# Altium schematic sheet NC_Connectors.SchDoc(NC_Connectors)
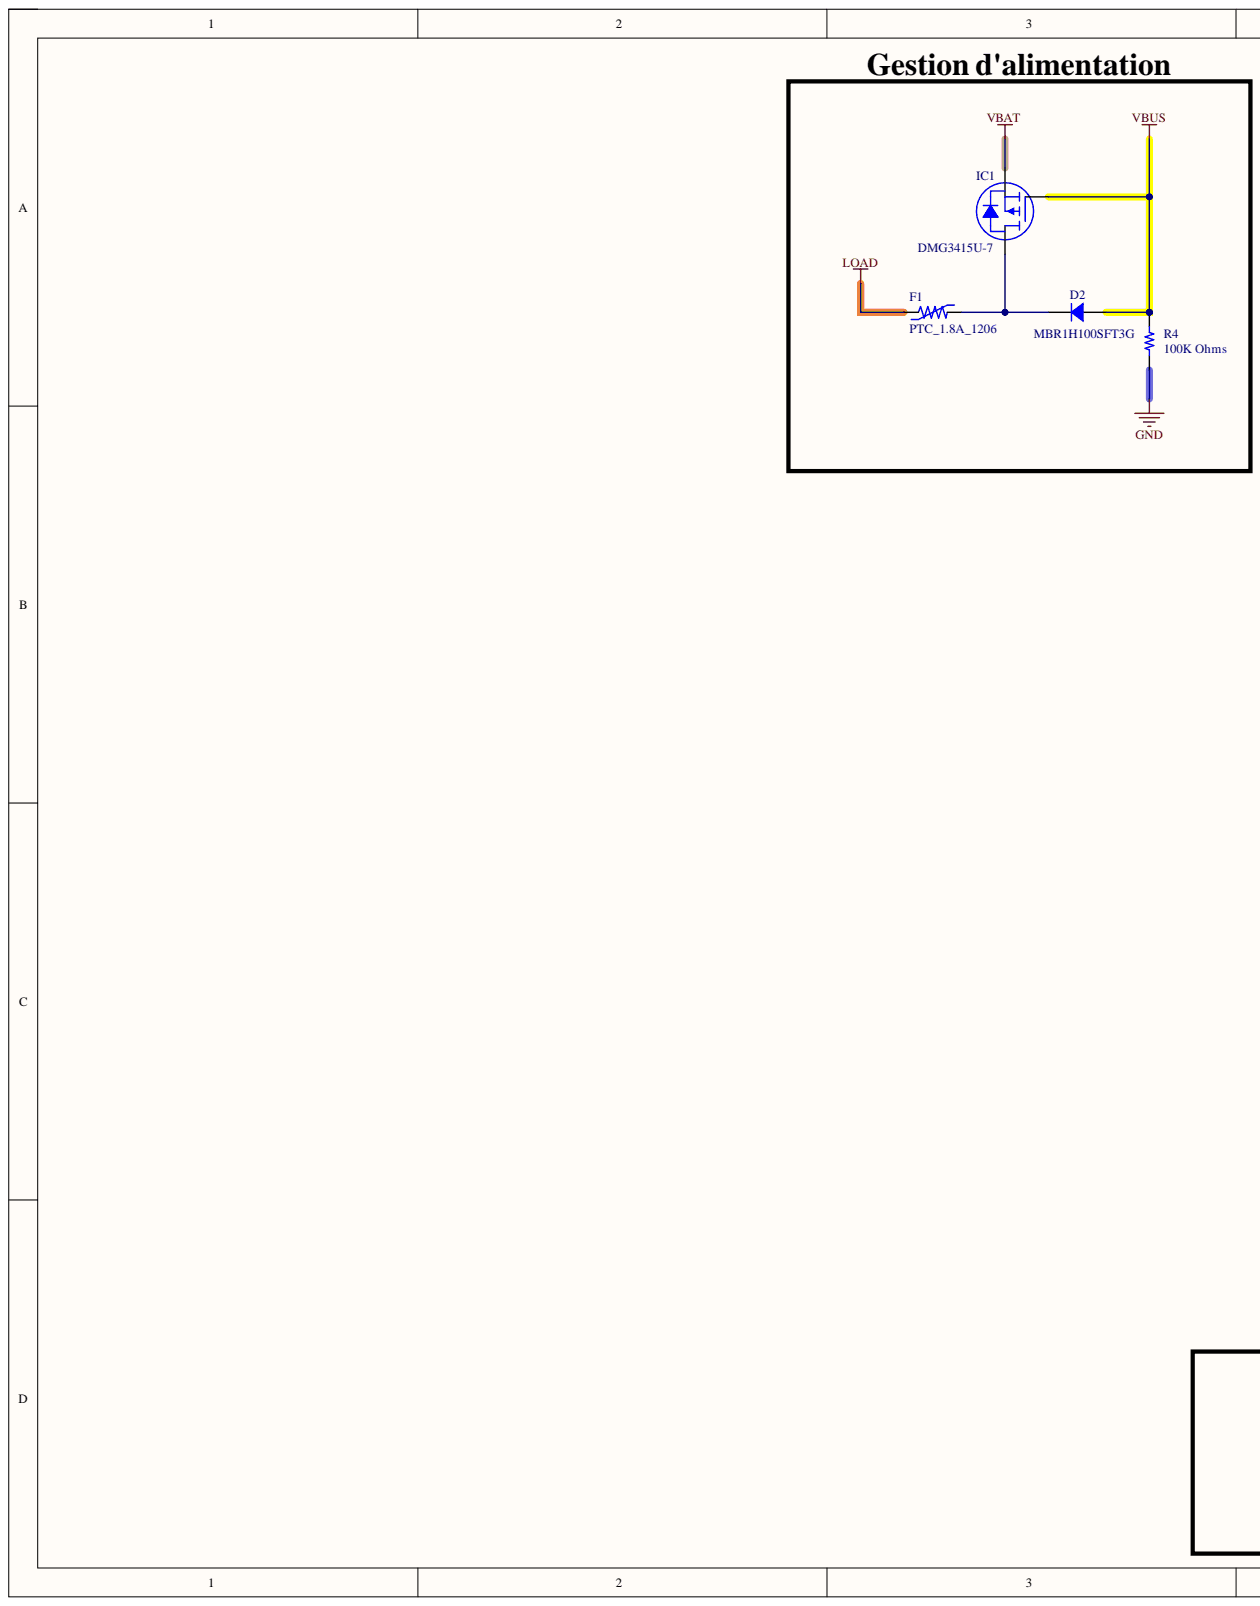
[diagram p0: NC_Connectors.SchDoc, left]
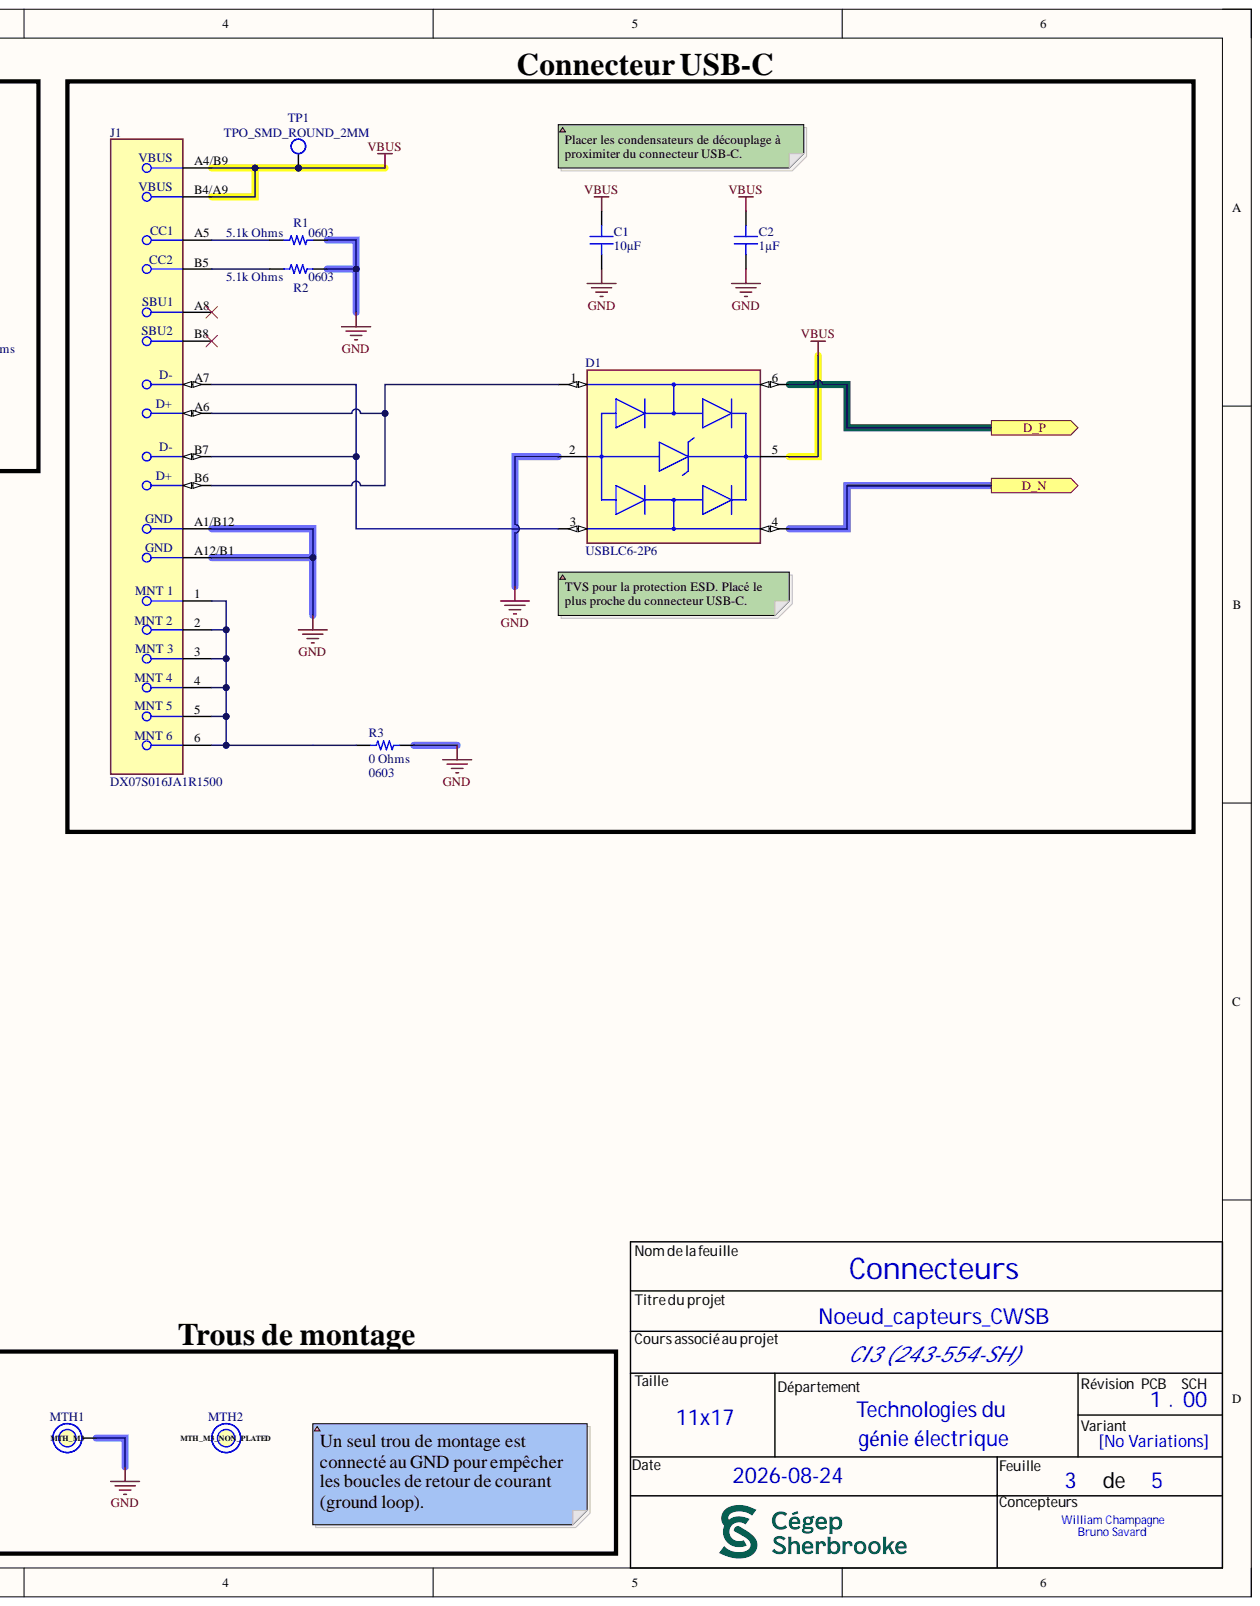
[diagram p1: NC_Connectors.SchDoc, right]

## Parts
C1  Capacitance: 10µF  [CAP 0603_1608]  (hidden comment: 1µF)
C2  1µF  [CAP 0603_1608]
D1  USBLC6-2P6  [FP-SOT-666-MFG]
D2  MBR1H100SFT3G  [SOD123F]
F1  PTC_1.8A_1206  [RESC3216X125N]
IC1  DMG3415U-7  [DIODES SOT-23-3]
J1  DX07S016JA1R1500  [FP-DX07S016JA1R1500-MFG]
MTH1  MTH_M3  [MTH_M3]  (no BOM)
MTH2  MTH_M3_NON_PLATED  [MTH_M3_NON_PLATED]  (no BOM)
R1  5.1k Ohms  Supplier Device Package: 0603  [RES 0603_1608]
R2  5.1k Ohms  Supplier Device Package: 0603  [RES 0603_1608]
R3  0 Ohms  Supplier Device Package: 0603  [RES 0603_1608]
R4  100K Ohms  [RES 0603_1608]
TP1  TPO_SMD_ROUND_2MM  [TPO_SMD_ROUND_2MM]  (no BOM)

## Ports
D_N, D_P

## Nets
GND: C1.1 C2.1 D1.2 J1.A1/B12 J1.A12/B1 MTH1.1 R1.2 R2.2 R3.2 R4.1
LOAD: F1.1
VBAT: IC1.2
VBUS: C1.2 C2.2 D1.5 D2.1 IC1.1 J1.A4/B9 J1.B4/A9 R4.2 TP1.1
D_N: D1.4 ; port D_N
D_P: D1.6 ; port D_P
NetD1_1: D1.1 J1.A6 J1.B6
NetD1_3: D1.3 J1.A7 J1.B7
NetD2_2: D2.2 F1.2 IC1.3
NetJ1_1: J1.1 J1.2 J1.3 J1.4 J1.5 J1.6 R3.1
NetJ1_A5: J1.A5 R1.1
NetJ1_B5: J1.B5 R2.1

Not connected: J1 A8 B8

## Library data of the parts
C1 C2 = 06035C105KAT2A: CAP CER 1UF 50V X7R 0603; manufacturer: AVX Corporation; Database Table Name: Capacitors - Ceramic - 0603; Tolerance: ±10%; Applications: General Purpose; Component Kind: Standard; componentlink1description: Datasheet; componentlink1url: http://datasheets.avx.com/X7RDielectric.pdf; componentlink2description: DigiKey Link; componentlink2url: http://digikey.com/product-detail/en/avx-corporation/06035C105KAT2A/478-10073-1-ND/6564295; Device Package: 0603 (1608 Metric); lastupdated: 2020-09-02 1:03:35 AM; Library Name: Celestial Altium Library - altium_library.DbLib; Lifecycle Status: Active; Minimum Order: 1; Mounting Type: Surface Mount, MLCC; Operating Temperature: -55°C ~ 125°C; Package / Case: 0603 (1608 Metric); packaging: TapeAndReel; Part Number: 06035C105KAT2A; Part Status: Active; partid: 2905; price: 0.15; series: -; Size / Dimension: 0.063" L x 0.032" W (1.60mm x 0.81mm); Supplier 1: DigiKey; Supplier Part Number 1: 478-10073-1-ND; Temperature Coefficient: X7R; Thickness (Max): 0.020" (0.50mm); value: 1µF; Voltage - Rated: 50V
  C2: Capacitance: 1µF
D1 = CMP-12225-000058-1: TVS DIODE 5.25V 17V SOT666; Breakdown Voltage: 6V; Capacitance: 3.5pF; Direction: Unidirectional; Element Configuration: Dual; ESD Protection: Yes; Leakage Current: 150nA; Number of Bidirectional Channels: 2; Number of Elements: 1; Operating Supply Voltage: 5V; Peak Pulse Current: 5A; Test Current: 1mA; Case/Package: SOT-666; Clamping Voltage: 17V; Component Kind: Standard; Contact Plating: Tin; Depth: 1.3mm; Height: 0.6mm; Lead Free: Lead Free; Length: 1.7mm; Library Name: Altium Content Vault; Max Breakdown Voltage: 6V; Max Operating Temperature: 125°C; Max Reverse Leakage Current: 150nA; Min Breakdown Voltage: 6V; Min Operating Temperature: -40°C; Mount: Surface Mount; Number of Channels: 2; Number of Pins: 6; Package Quantity: 1; Packaging: Tape and Reel; Polarity: Unidirectional; Power Line Protection: Yes; Radiation Hardening: No; REACH SVHC: No SVHC; Reverse Breakdown Voltage: 6V; Reverse Standoff Voltage: 5V; Termination: SMD/SMT; Width: 1.3mm; Working Voltage: 5V
D2 = MBR1H100SFT3G: DIODE SCHOTTKY 100V 1A SOD123FL; Manufacturer: ON Semiconductor; Current - Reverse Leakage @ Vr: 40µA @ 100V; Database Table Name: Diodes - Rectifiers - Single; Speed: Fast Recovery =< 500ns, > 200mA (Io); Voltage - Forward (Vf) (Max) @ If: 760mV @ 1A; Component Kind: Standard; ComponentLink1Description: Datasheet; ComponentLink1URL: http://www.onsemi.com/pub/Collateral/MBR1H100SF-D.PDF; ComponentLink2Description: DigiKey Link; ComponentLink2URL: http://digikey.com/product-detail/en/on-semiconductor/MBR1H100SFT3G/MBR1H100SFT3GOSCT-ND/3462287; Current - Average Rectified (Io): 1A; Diode Type: Schottky; LastUpdated: 2026-04-05 8:31:56 PM; Library Name: Celestial Altium Library - altium_library.DbLib; Lifecycle Status: Active; Minimum Order: 1; Mounting Type: Surface Mount; Operating Temperature - Junction: -65°C ~ 175°C; Package / Case: SOD-123F; Packaging: TapeAndReel; Part Number: MBR1H100SFT3G; Part Status: Active; PartId: 2398; Price: 0.14; Series: -; Supplier 1: DigiKey; Supplier Device Package: SOD-123FL; Supplier Part Number 1: MBR1H100SFT3GOSCT-ND; Voltage - DC Reverse (Vr) (Max): 100V
F1 = CMP-005-000017-6: PTC Resettable Fuse 1A(hold) 1.8A(trip) 6VDC 100A 0.6W 0.3s 0.55Ohm SMD Solder Pad 1206 T/R Automotive; Maximum Resistance 1 Hour (R1max): 210mΩ; MF: Bel Fuse; MP: 0ZCJ0100FF2E; Resistance: 55mΩ; Time to Trip: 300ms; Working Voltage: 6V; Availability: Good; Case Code (Imperial): 1206; Case Code (Metric): 3216; Case/Package: 1206; Check_prices: https://www.snapeda.com/parts/0ZCJ0100FF2E/Bel%20Fuse%20Inc./view-part/970476/?ref=eda; China RoHS: Compliant; Component Kind: Standard; Component Type: Standard; Design Item ID: 0ZCJ0100FF2E; DigiKey-Purchase-URL: https://snapeda.com/shop?store=DigiKey&id=970476; Height: 1mm; Hold Current: 1A; Lead Free: Lead Free; Lifecycle Status: Production; Max Current Rating: 100A; Max Operating Temperature: 85°C; Min Operating Temperature: -40°C; Mount: Surface Mount; Mouser-Purchase-URL: https://snapeda.com/shop?store=Mouser&id=970476; Number of Terminals: 2; Package: 1206 Bel Fuse; Packaging: Tape & Reel (TR); Pin Count: 2; Price: None; REACH SVHC: Yes; RoHS: Compliant; SnapEDA_Link: https://www.snapeda.com/parts/0ZCJ0100FF2E/Bel%20Fuse%20Inc./view-part/970476/?ref=snap; Source: 0ZCJ0100FF2E.SchLib; Trip Current: 1.8A; Width: 1.8mm; Library Name: Cegep de Sherbrooke
IC1 = DMG3415U-7: MOSFET P-CH 20V 4A SOT23-3; Manufacturer: Diodes Incorporated; Current - Continuous Drain (Id) @ 25°C: 4A (Ta); Database Table Name: MOSFET - Single - P-Ch; Drive Voltage (Max Rds On,  Min Rds On): 1.8V, 4.5V; FET Type: P-Channel; Gate Charge (Qg) (Max) @ Vgs: 9.1 nC @ 4.5 V; Input Capacitance (Ciss) (Max) @ Vds: 294 pF @ 10 V; Power Dissipation (Max): 900mW (Ta); Rds On (Max) @ Id, Vgs: 39mOhm @ 4A, 4.5V; Vgs (Max): ±8V; Vgs(th) (Max) @ Id: 1V @ 250µA; Component Kind: Standard; ComponentLink1Description: Datasheet; ComponentLink1URL: //media.digikey.com/pdf/Data%20Sheets/Diodes%20PDFs/DMG3415U.pdf; ComponentLink2Description: DigiKey Link; ComponentLink2URL: https://www.digikey.com/en/products/detail/diodes-incorporated/DMG3415U-7/2052768; Drain to Source Voltage (Vdss): 20 V; LastUpdated: 2026-04-05 8:53:25 PM; Library Name: Celestial Altium Library - altium_library.DbLib; Lifecycle Status: Active; Minimum Order: 1; Mounting Type: Surface Mount; Operating Temperature: -55°C ~ 150°C (TJ); Package / Case: TO-236-3, SC-59, SOT-23-3; Packaging: TapeAndReel; Part Number: DMG3415U-7; Part Status: Active; Price: 0.07; Series: -; Supplier 1: DigiKey; Supplier Device Package: SOT-23-3; Supplier Part Number 1: DMG3415UDICT-ND; Technology: MOSFET (Metal Oxide)
J1 = CMP-19038-000003-1: CONN RCP USB2.0 TYP C 24P SMD RA; Component Kind: Standard; Library Name: Altium Content Vault
MTH1 = CMP-006-000008-2: MTH - MOUNTING HOLE M3 PLATED - M3; Assembly Type: NONE; Component Type: Standard (No BOM); Design Item ID: MTH_M3; HelpURL: https://littlemachineshop.com/images/gallery/PDF/TapDrillSizes.pdf; Package: M3; Pin Count: 1; Library Name: Cegep de Sherbrooke; Source: CEGEP_SHERBROOKE_TGE_LIBRARY.SchLib
MTH2 = CMP-006-000010-2: MTH - MOUNTING HOLE M3 NON-PLATED - M3; Assembly Type: NONE; Component Type: Standard (No BOM); Design Item ID: MTH_M3_NON_PLATED; HelpURL: https://littlemachineshop.com/images/gallery/PDF/TapDrillSizes.pdf; Package: M3; Pin Count: 0; Library Name: Cegep de Sherbrooke; Source: CEGEP_SHERBROOKE_TGE_LIBRARY.SchLib
R1-R4 = ERJ-UP3J151V: RES 150 OHM 5% 1/4W 0603; Manufacturer: Panasonic Electronic Components; Database Table Name: Resistors - Surface Mount - 0603; Power (Watts): 0.25W, 1/4W; Temperature Coefficient: ±200ppm/°C; Component Kind: Standard; ComponentLink1Description: Datasheet; ComponentLink1URL: https://b2b-api.panasonic.eu/file_stream/pids/fileversion/4484; ComponentLink2Description: DigiKey Link; ComponentLink2URL: https://www.digikey.com/en/products/detail/panasonic-electronic-components/ERJ-UP3J151V/12092481; Composition: Thick Film; Device Package: 0603 (1608 Metric); Features: Anti-Sulfur, Automotive AEC-Q200, Pulse Withstanding; Height - Seated (Max): 0.022" (0.55mm); Library Name: Celestial Altium Library - altium_library.DbLib; Lifecycle Status: Active; Minimum Order: 1; Number of Terminations: 2; Operating Temperature: -55°C ~ 155°C; Package / Case: 0603 (1608 Metric); Packaging: TapeAndReel; Part Number: ERJ-UP3J151V; Part Status: Active; PartId: 63618; Price: 0.02; Ratings: AEC-Q200; Series: ERJ-UP3; Size / Dimension: 0.063" L x 0.031" W (1.60mm x 0.80mm); Supplier 1: DigiKey; Supplier Part Number 1: 10-ERJ-UP3J151VCT-ND; Tolerance: ±5%
  R1 R2: LastUpdated: 2024-02-09 8:41:36 PM; Resistance: 5.1k Ohms; Value: 5.1k Ohms
  R3: LastUpdated: 2024-02-09 8:41:36 PM; Resistance: 0 Ohms; Value: 0 Ohms
  R4: LastUpdated: 09/02/2024 20:41:36; Resistance: 100K Ohms; Supplier Device Package: 0603; Value: 100K Ohms
TP1 = CMP-007-000003-1: TPO - TEST POINT ROUND SMD PAD ONLY  - 2 MM; Assembly Type: NONE; Component Type: Standard (No BOM); Design Item ID: TPO_SMD_ROUND_2MM; Package: 2 MM ROUND; Pin Count: 1; Library Name: Cegep de Sherbrooke; Source: CEGEP_SHERBROOKE_TGE_LIBRARY.SchLib
